# Altium schematic sheet MCU.SchDoc(MCU)
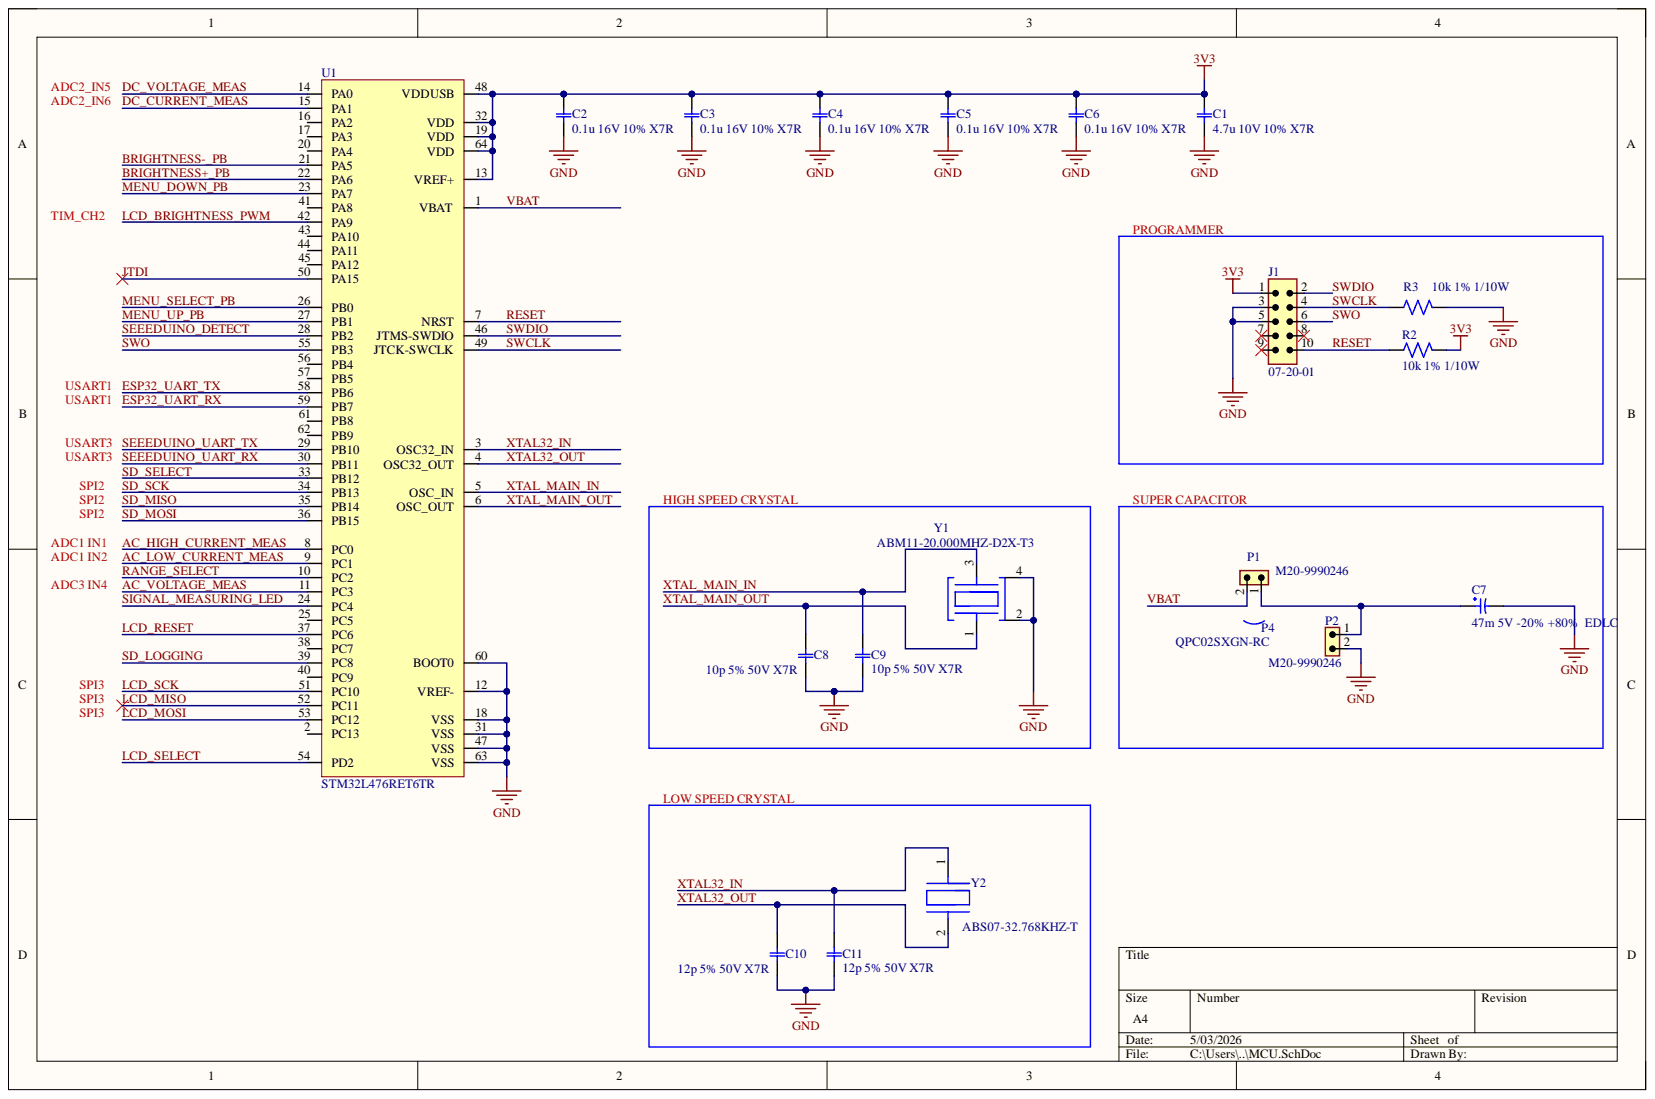
[diagram p0: MCU.SchDoc]

## Parts
C1  4.7u 10V 10% X7R  [0603-C]
C2  0.1u 16V 10% X7R  [0603-C]
C3  0.1u 16V 10% X7R  Dielectric: X7R  [0603-C]
C4  0.1u 16V 10% X7R  Dielectric: X7R  [0603-C]
C5  0.1u 16V 10% X7R  Dielectric: X7R  [0603-C]
C6  0.1u 16V 10% X7R  Dielectric: X7R  [0603-C]
C7  47m 5V -20% +80%  EDLC  [FC0H473ZFTBR24]
C8  10p 5% 50V X7R  value 10p  [0805-C]
C9  10p 5% 50V X7R  value 10p  [0805-C]
C10  12p 5% 50V X7R  value 12p  [0805-C]
C11  12p 5% 50V X7R  value 12p  [0805-C]
J1  07-20-01  [07-20-01]
P1  M20-9990246  [M20-9990246]
P2  M20-9990246  [M20-9990246]
P4  QPC02SXGN-RC  (mechanical)
R2  10k 1% 1/10W  Resistance Tolerance: 1%  [0603-R]
R3  10k 1% 1/10W  Resistance Tolerance: 1%  [0603-R]
U1  STM32L476RET6TR  [STM32L476RET6TR]
Y1  ABM11-20.000MHZ-D2X-T3  [ABM11-20.000MHZ-D2X-T3]
Y2  ABS07-32.768KHZ-T  [ABS07-32.768KHZ-T]

## Nets
3V3: C1.1 C2.1 C3.1 C4.1 C5.1 C6.1 J1.1 R2.1 U1.13(VREF+) U1.19(VDD) U1.32(VDD) U1.48(VDDUSB) U1.64(VDD)
GND: C1.2 C2.2 C3.2 C4.2 C5.2 C6.2 C7.1 C8.1 C9.2 C10.1 C11.1 J1.3 J1.5 P2.2 R3.1 U1.12(VREF-) U1.18(VSS) U1.31(VSS) U1.47(VSS) U1.60(BOOT0) U1.63(VSS) Y1.2 Y1.4
AC_HIGH_CURRENT_MEAS: U1.8(PC0)
AC_LOW_CURRENT_MEAS: U1.9(PC1)
AC_VOLTAGE_MEAS: U1.11(PC3)
BRIGHTNESS+_PB: U1.22(PA6)
BRIGHTNESS-_PB: U1.21(PA5)
DC_CURRENT_MEAS: U1.15(PA1)
DC_VOLTAGE_MEAS: U1.14(PA0)
ESP32_UART_RX: U1.59(PB7)
ESP32_UART_TX: U1.58(PB6)
JTDI: U1.50(PA15)
LCD_BRIGHTNESS_PWM: U1.42(PA9)
LCD_MISO: U1.52(PC11)
LCD_MOSI: U1.53(PC12)
LCD_RESET: U1.37(PC6)
LCD_SCK: U1.51(PC10)
LCD_SELECT: U1.54(PD2)
MENU_DOWN_PB: U1.23(PA7)
MENU_SELECT_PB: U1.26(PB0)
MENU_UP_PB: U1.27(PB1)
RANGE_SELECT: U1.10(PC2)
RESET: J1.10 R2.2 U1.7(NRST)
SD_LOGGING: U1.39(PC8)
SD_MISO: U1.35(PB14)
SD_MOSI: U1.36(PB15)
SD_SCK: U1.34(PB13)
SD_SELECT: U1.33(PB12)
SEEEDUINO_DETECT: U1.28(PB2)
SEEEDUINO_UART_RX: U1.30(PB11)
SEEEDUINO_UART_TX: U1.29(PB10)
SIGNAL_MEASURING_LED: U1.24(PC4)
SWCLK: J1.4 R3.2 U1.49(JTCK-SWCLK)
SWDIO: J1.2 U1.46(JTMS-SWDIO)
SWO: J1.6 U1.55(PB3)
VBAT: P1.2 U1.1(VBAT)
XTAL32_IN: C11.2 U1.3(OSC32_IN) Y2.1
XTAL32_OUT: C10.2 U1.4(OSC32_OUT) Y2.2
XTAL_MAIN_IN: C9.1 U1.5(OSC_IN) Y1.3
XTAL_MAIN_OUT: C8.2 U1.6(OSC_OUT) Y1.1
NetC7_2: C7.2 P1.1 P2.1

Not connected: J1 7 8 9; U1 2(PC13) 16(PA2) 17(PA3) 20(PA4) 25(PC5) 38(PC7) 40(PC9) 41(PA8) 43(PA10) 44(PA11) 45(PA12) 56(PB4) 57(PB5) 61(PB8) 62(PB9)

## Library data of the parts
C1 = GMC10X7R475K10NT: Ceramic Capacitor, Multilayer, Ceramic, 10V, 10% +Tol, 10% -Tol, X7R, 15% TC, 4.7uF, Surface Mount, 0603; Manufacturer: Cal-Chip Electronics; Value: 4.7µF; Category: Ceramic Capacitors; China RoHS: Compliant; Component Kind: Standard; ComponentLink1Description: https://datasheet.octopart.com:443/GMC10X7R475K10NT-Cal-Chip-Electronics-datasheet-182028815.pdf?src-supplier=IHS; ComponentLink1URL: https://datasheet.octopart.com:443/GMC10X7R475K10NT-Cal-Chip-Electronics-datasheet-182028815.pdf?src-supplier=IHS; Dielectric Material: Ceramic; Height: 900µm; Length: 1.6mm; Lifecycle Status: Production; Manufacturer Part Number: GMC10X7R475K10NT; Max Operating Temperature: 125°C; Min Operating Temperature: -55°C; Mounting Technology: Surface Mount; Number of Terminals: 2; Pricing: 1=0.26, 10=0.154, 50=0.1112, 100=0.0982, 500=0.07628, 1000=0.06951 (USD); REACH SVHC: Yes; RoHS: Compliant; Stock: 238375; Supplier 1: DigiKey; Supplier Part Number 1: 4713-GMC10X7R475K10NTCT-ND; Voltage Rating (DC): 10V; Width: 800µm; Library Name: tpmania.SchLib
C2-C6 = CC0603KRX7R7BB104: 0603 0.1 uF 16 V ±10 % Tolerance X7R SMT Multilayer Ceramic Capacitor; Manufacturer: Yageo; Insulation Resistance: 100GR; Value: 100nF; Case Code (Imperial): 0603; Case Code (Metric): 1608; Case/Package: 0603; Category: Ceramic Capacitors; Component Kind: Standard; ComponentLink1Description: https://datasheet.ciiva.com/11786/0900766b80d84f1d-11786077.pdf?src-supplier=Rs; ComponentLink1URL: https://datasheet.ciiva.com/11786/0900766b80d84f1d-11786077.pdf?src-supplier=Rs; ComponentLink2Description: https://datasheet.ciiva.com/144/mlccorderingcode-144182.pdf?src-supplier=Digikey; ComponentLink2URL: https://datasheet.ciiva.com/144/mlccorderingcode-144182.pdf?src-supplier=Digikey; ComponentLink3Description: https://datasheet.ciiva.com/144/mlccorderingcode-144183.pdf?src-supplier=Digikey; ComponentLink3URL: https://datasheet.ciiva.com/144/mlccorderingcode-144183.pdf?src-supplier=Digikey; ComponentLink4Description: https://datasheet.ciiva.com/144/mlccorderingcode-144184.pdf?src-supplier=Digikey; ComponentLink4URL: https://datasheet.ciiva.com/144/mlccorderingcode-144184.pdf?src-supplier=Digikey; ComponentLink5Description: https://datasheet.ciiva.com/7978/1489800-7978141.pdf?src-supplier=Element14; ComponentLink5URL: https://datasheet.ciiva.com/7978/1489800-7978141.pdf?src-supplier=Element14; ComponentLink6Description: https://datasheet.ciiva.com/11464/upy-gphc-x7r-6-3v-to-50v-8-11464735.pdf?src-supplier=Avnet; ComponentLink6URL: https://datasheet.ciiva.com/11464/upy-gphc-x7r-6-3v-to-50v-8-11464735.pdf?src-supplier=Avnet; ComponentLink7Description: https://datasheet.ciiva.com/28450/1489800-28450552.pdf?src-supplier=Element14; ComponentLink7URL: https://datasheet.ciiva.com/28450/1489800-28450552.pdf?src-supplier=Element14; ComponentLink8Description: https://datasheet.ciiva.com/46430/1734573-46430156.pdf?src-supplier=Element14; ComponentLink8URL: https://datasheet.ciiva.com/46430/1734573-46430156.pdf?src-supplier=Element14; ComponentLink9Description: https://datasheet.ciiva.com/8033/1734573-8033797.pdf?src-supplier=Element14; ComponentLink9URL: https://datasheet.ciiva.com/8033/1734573-8033797.pdf?src-supplier=Element14; ComponentLink10Description: https://datasheet.ciiva.com/8033/70765-8033799.pdf?src-supplier=Element14; ComponentLink10URL: https://datasheet.ciiva.com/8033/70765-8033799.pdf?src-supplier=Element14; ComponentLink11Description: https://datasheet.ciiva.com/15165/getdatasheetpartid-63554-15165387.pdf?src-supplier=Verical; ComponentLink11URL: https://datasheet.ciiva.com/15165/getdatasheetpartid-63554-15165387.pdf?src-supplier=Verical; ComponentLink12Description: https://datasheet.ciiva.com/13395/upy-gphc-x7r-6-3v-to-50v-8-13395674.pdf?src-supplier=Mouser; ComponentLink12URL: https://datasheet.ciiva.com/13395/upy-gphc-x7r-6-3v-to-50v-8-13395674.pdf?src-supplier=Mouser; Depth: 0.8mm; Halogen Free: Halogen Free; Height: 0.8mm; Lead Free: Lead Free; Length: 1.6mm; Manufacturer Part Number: CC0603KRX7R7BB104; Material: Ceramic; Max Operating Temperature: 125°C; Min Operating Temperature: -55°C; Mounting Technology: Surface Mount; Package Quantity: 1; Packaging: Tape and Reel; Pins: 2; Pricing: 1=0.08, 10=0.014, 50=0.012, 100=0.0096, 500=0.0072, 1000=0.0064 (USD); Radiation Hardening: No; Reach SVHC Compliant: No; RoHS: Yes; RoHS Compliant: Yes; Series: CC; Stock: 7111175; Supplier 1: Digikey; Supplier Part Number 1: 311-1088-1-ND; Termination: SMD/SMT; Thickness: 0.035inch; Tolerance: 10%; Voltage: 16V; Voltage Rating: 16V; Voltage Rating (DC): 16V; Width: 0.031inch; Library Name: tpmania.SchLib
  C2: Dielectric: X7R
C7 = FC0H473ZFTBR24: Cap Supercap 47000uF 5.5V -20% to 80% (10.5 X 5.5mm) SMD Cylindrical 50 Ohm 70C T/R; Manufacturer: KEMET; ESR (Equivalent Series Resistance): 50Ω; Value: 47mF; Category: Electric Double Layer Capacitors; Component Kind: Standard; ComponentLink1Description: https://datasheet.octopart.com:443/FC0H473ZFTBR24-KEMET-datasheet-176830268.pdf?src-supplier=IHS; ComponentLink1URL: https://datasheet.octopart.com:443/FC0H473ZFTBR24-KEMET-datasheet-176830268.pdf?src-supplier=IHS; ComponentLink2Description: https://datasheet.octopart.com:443/FC0H473ZFTBR24-KEMET-datasheet-140123098.pdf?src-supplier=Farnell; ComponentLink2URL: https://datasheet.octopart.com:443/FC0H473ZFTBR24-KEMET-datasheet-140123098.pdf?src-supplier=Farnell; ComponentLink3Description: https://datasheet.octopart.com:443/FC0H473ZFTBR24-KEMET-datasheet-101149853.pdf?src-supplier=Farnell; ComponentLink3URL: https://datasheet.octopart.com:443/FC0H473ZFTBR24-KEMET-datasheet-101149853.pdf?src-supplier=Farnell; ComponentLink4Description: https://datasheet.octopart.com:443/FC0H473ZFTBR24-KEMET-datasheet-181965052.pdf?src-supplier=Newark; ComponentLink4URL: https://datasheet.octopart.com:443/FC0H473ZFTBR24-KEMET-datasheet-181965052.pdf?src-supplier=Newark; ComponentLink5Description: https://datasheet.octopart.com:443/FC0H473ZFTBR24-KEMET-datasheet-181965051.pdf?src-supplier=Newark; ComponentLink5URL: https://datasheet.octopart.com:443/FC0H473ZFTBR24-KEMET-datasheet-181965051.pdf?src-supplier=Newark; ComponentLink6Description: https://datasheet.octopart.com:443/FC0H473ZFTBR24-Kemet-datasheet-20803799.pdf?src-supplier=Newark; ComponentLink6URL: https://datasheet.octopart.com:443/FC0H473ZFTBR24-Kemet-datasheet-20803799.pdf?src-supplier=Newark; ComponentLink7Description: https://datasheet.octopart.com:443/FC0H473ZFTBR24-KEMET-datasheet-76800834.pdf?src-supplier=Newark; ComponentLink7URL: https://datasheet.octopart.com:443/FC0H473ZFTBR24-KEMET-datasheet-76800834.pdf?src-supplier=Newark; ComponentLink8Description: https://datasheet.octopart.com:443/FC0H473ZFTBR24-KEMET-datasheet-76759449.pdf?src-supplier=Newark; ComponentLink8URL: https://datasheet.octopart.com:443/FC0H473ZFTBR24-KEMET-datasheet-76759449.pdf?src-supplier=Newark; ComponentLink9Description: https://datasheet.octopart.com:443/FC0H473ZFTBR24-Kemet-datasheet-62307655.pdf?src-supplier=element14+APAC; ComponentLink9URL: https://datasheet.octopart.com:443/FC0H473ZFTBR24-Kemet-datasheet-62307655.pdf?src-supplier=element14+APAC; ComponentLink10Description: https://datasheet.octopart.com:443/FC0H473ZFTBR24-Kemet-datasheet-62115098.pdf?src-supplier=element14+APAC; ComponentLink10URL: https://datasheet.octopart.com:443/FC0H473ZFTBR24-Kemet-datasheet-62115098.pdf?src-supplier=element14+APAC; ComponentLink11Description: https://datasheet.octopart.com:443/FC0H473ZFTBR24-KEMET-datasheet-104529960.pdf?src-supplier=TME; ComponentLink11URL: https://datasheet.octopart.com:443/FC0H473ZFTBR24-KEMET-datasheet-104529960.pdf?src-supplier=TME; ComponentLink12Description: https://datasheet.octopart.com:443/FC0H473ZFTBR24-KEMET-datasheet-104202293.pdf?src-supplier=TME; ComponentLink12URL: https://datasheet.octopart.com:443/FC0H473ZFTBR24-KEMET-datasheet-104202293.pdf?src-supplier=TME; Diameter: 10.5mm; Height: 5.5mm; Length: 10.8mm; Library Name: ENGG3800 schematic library.SchLib; Lifecycle Status: Production; Manufacturer Part Number: FC0H473ZFTBR24; Max Operating Temperature: 70°C; Min Operating Temperature: -25°C; Mounting Technology: Surface Mount; Pricing: 1=2.64, 10=1.822, 50=1.4956, 100=1.3949, 500=1.22452 (USD); REACH SVHC: Yes; RoHS: Compliant; Schedule B: 8532290040; Stock: 7158; Supplier 1: DigiKey; Supplier Part Number 1: 399-10938-1-ND; Voltage Rating (DC): 5.5V; Width: 10.8mm
C8 C9 = 64-02-01: Component Kind: Standard; Library Name: ENGG3800 schematic library.SchLib; Supplier 1: etsg; Supplier Part Number 1: 64-02-01
C10 C11 = 64-02-02: Component Kind: Standard; Library Name: ENGG3800 schematic library.SchLib; Supplier 1: etsg; Supplier Part Number 1: 64-02-02
J1 = 07-20-01: HEADER: J-link Connector / 10 pins / 1.27mm / Box Header / Through Hole; Component Kind: Standard; Library Name: ENGG3800 schematic library.SchLib; Supplier 1: etsg; Supplier Part Number 1: 07-20-01
P1 P2 = M20-9990246: 2.54mm (0.1") Pitch SIL Vertical PC Tail Pin Header, 6.1mm mating pin height, tin, 2 contacts; Manufacturer: Harwin; Connector Type: Male Pin; Contact Resistance: 30mΩ; Current Rating: 3A; Dielectric Material: Polyamide; Gender: Male; Insulation Resistance: 1GΩ; Number of Contacts: 2; Voltage Rating (AC): 750V; Case/Package: SIL; Category: Headers and Wire Housings; Color: Black; Component Kind: Standard; ComponentLink1Description: https://datasheet.octopart.com:443/M20-9990246-Harwin-datasheet-169407857.pdf?src-supplier=Newark; ComponentLink1URL: https://datasheet.octopart.com:443/M20-9990246-Harwin-datasheet-169407857.pdf?src-supplier=Newark; ComponentLink2Description: https://datasheet.octopart.com:443/M20-9990246-Harwin-datasheet-27378916.pdf?src-supplier=Newark; ComponentLink2URL: https://datasheet.octopart.com:443/M20-9990246-Harwin-datasheet-27378916.pdf?src-supplier=Newark; ComponentLink3Description: https://datasheet.octopart.com:443/M20-9990246-Harwin-datasheet-96624206.pdf?src-supplier=element14+APAC; ComponentLink3URL: https://datasheet.octopart.com:443/M20-9990246-Harwin-datasheet-96624206.pdf?src-supplier=element14+APAC; ComponentLink4Description: https://datasheet.octopart.com:443/M20-9990246-Harwin-datasheet-32864541.pdf?src-supplier=Farnell; ComponentLink4URL: https://datasheet.octopart.com:443/M20-9990246-Harwin-datasheet-32864541.pdf?src-supplier=Farnell; ComponentLink5Description: https://datasheet.octopart.com:443/M20-9990246-Harwin-datasheet-7538443.pdf?src-supplier=Farnell; ComponentLink5URL: https://datasheet.octopart.com:443/M20-9990246-Harwin-datasheet-7538443.pdf?src-supplier=Farnell; ComponentLink6Description: https://datasheet.octopart.com:443/M20-9990246-Harwin-datasheet-508868.pdf?src-supplier=Farnell; ComponentLink6URL: https://datasheet.octopart.com:443/M20-9990246-Harwin-datasheet-508868.pdf?src-supplier=Farnell; Contact Gender: Male; Contact Material: Brass; Contact Mating Length: 6.096mm; Contact Plating: Tin; Country of Origin: Taiwan; Depth: 2.54mm; Export Control Classification Number (ECCN) Code: EAR99; Harmonized Tariff Schedule (HTS) Code: 8536.69.40.40; Height: 8.99mm; Housing Color: Black; Housing Material: Nylon; Introduction Date: 2005-06-27; Lead Pitch: 2.54mm; Length: 5.08mm; Library Name: ENGG3800 schematic library.SchLib; Lifecycle Status: Production; Manufacturer Part Number: M20-9990246; Max Current Rating: 3A; Max Operating Temperature: 105°C; Min Operating Temperature: -40°C; Mounting Technology: Through Hole; Number of Positions: 2; Number of Rows: 1; Orientation: Straight; Packaging: Bulk; Pins: 2; Pitch: 2.54mm; Pricing: 1=0.1, 10=0.079, 100=0.0669, 500=0.0597, 1000=0.05684, 5000=0.05073, 10000=0.04831 (USD); Radiation Hardening: No; REACH SVHC: Yes; RoHS: Compliant; Stock: 152704; Supplier 1: DigiKey; Supplier Part Number 1: 952-2262-ND; Terminal Pitch: 2.54mm; Termination: Solder
P4 = QPC02SXGN-RC: 2 Position 2.54 mm Centerline Single Circuit Open Top Jumper; Manufacturer: Sullins; Current Rating: 3A; Number of Contacts: 2; Pitch: 2.54mm; Case/Package: 100; Category: Shunts and Jumpers; ComponentLink1Description: https://datasheet.octopart.com:443/QPC02SXGN-RC-Sullins-datasheet-138898435.pdf?src-supplier=ODG+(Origin+Data+Global); ComponentLink1URL: https://datasheet.octopart.com:443/QPC02SXGN-RC-Sullins-datasheet-138898435.pdf?src-supplier=ODG+(Origin+Data+Global); ComponentLink2Description: https://datasheet.octopart.com:443/QPC02SXGN-RC-Sullins-datasheet-12521006.pdf?src-supplier=IHS; ComponentLink2URL: https://datasheet.octopart.com:443/QPC02SXGN-RC-Sullins-datasheet-12521006.pdf?src-supplier=IHS; ComponentLink3Description: https://sigma.octopart.com:443/138898456/technical_drawing/Sullins-QPC02SXGN-RC.pdf?src-supplier=Upverter; ComponentLink3URL: https://sigma.octopart.com:443/138898456/technical_drawing/Sullins-QPC02SXGN-RC.pdf?src-supplier=Upverter; ComponentLink4Description: https://datasheet.octopart.com:443/QPC02SXGN-RC-Sullins-datasheet-41399305.pdf?src-supplier=Future+Electronics; ComponentLink4URL: https://datasheet.octopart.com:443/QPC02SXGN-RC-Sullins-datasheet-41399305.pdf?src-supplier=Future+Electronics; Contact Gender: Female; Contact Material: Brass; Contact Plating: Gold; Height: 6.17mm; Lead Free: Lead Free; Library Name: ENGG3800 schematic library.SchLib; Lifecycle Status: Production; Manufacturer Part Number: QPC02SXGN-RC; Max Operating Temperature: 85°C; Min Operating Temperature: -40°C; Number of Positions: 2; Packaging: Bulk; Pins: 1; Pricing: 1=0.1, 10=0.028, 25=0.026, 50=0.025, 100=0.0237, 250=0.02224, 500=0.02116, 2000=0.01918, 4000=0.01826 (USD); REACH SVHC: Yes; RoHS: Compliant; Stock: 1035793; Supplier 1: DigiKey; Supplier Part Number 1: S9337-ND
R2 R3 = RK73H1JTTD1002F: Resistor Thick Film 0603 10K Ohm 1% 1/10W ±100ppm/°C SMD Punched Paper Tape and Reel; Manufacturer: KOA Speer; Max Power Dissipation: 100mW; Value: 10kR; Voltage Rating: 50V; Case Code (Imperial): 0603; Case Code (Metric): 1608; Case/Package: 0603; Category: Chip SMD Resistors; Component Kind: Standard; ComponentLink1Description: https://datasheet.ciiva.com/5556/rk73h-5556565.pdf?src-supplier=Mouser; ComponentLink1URL: https://datasheet.ciiva.com/5556/rk73h-5556565.pdf?src-supplier=Mouser; ComponentLink2Description: https://datasheet.ciiva.com/48426/rk73h-series-eng-jap-tds-48426520.pdf?src-supplier=Distrelec; ComponentLink2URL: https://datasheet.ciiva.com/48426/rk73h-series-eng-jap-tds-48426520.pdf?src-supplier=Distrelec; ComponentLink3Description: https://datasheet.ciiva.com/7773/549017-7773368.pdf?src-supplier=Element14; ComponentLink3URL: https://datasheet.ciiva.com/7773/549017-7773368.pdf?src-supplier=Element14; ComponentLink4Description: https://datasheet.ciiva.com/15159/getdatasheetpartid-89857-15159884.pdf?src-supplier=Verical; ComponentLink4URL: https://datasheet.ciiva.com/15159/getdatasheetpartid-89857-15159884.pdf?src-supplier=Verical; Construction: Rectangular; Depth: 0.8mm; Features: Moisture Resistant,Automotive AEC-Q200; Height: 0.45mm; Lead Free: Lead Free; Length: 1.6mm; Manufacturer Part Number: RK73H1JTTD1002F; Max Operating Temperature: 155°C; Military Standard: Not; Min Operating Temperature: -55°C; Number of Terminations: 2; Package Quantity: 5000; Packaging: Tape and Reel; Pins: 2; Power Rating: 100mW; Pricing: 1=0.1, 10=0.026, 100=0.0124, 1000=0.0068, 2500=0.00557 (USD); Ratings: AEC-Q200; Reach SVHC Compliant: Unknown; Resistor Type: Thick Film; RoHS: Yes; RoHS Compliant: Yes; Series: RK73H; Stock: 1507817; Supplier 1: Digikey; Supplier Part Number 1: 2019-RK73H1JTTD1002FCT-ND; Temperature Coefficient: 100ppm/°C; Termination: SMD/SMT; Tolerance: 1%; Voltage Rating (DC): 50V; Width: 0.8mm; Library Name: tpmania.SchLib
U1 = STM32L476RET6TR: Ultra-low-power with FPU ARM Cortex-M4 MCU 80 MHz with 512 Kbytes Flash, LCD, USB OTG; Manufacturer: STMicroelectronics; ADC Channels (Resolution): 16-Ch 12-Bit; Clock Frequency: 48MHz; DAC Channels (Resolution): 2 Ch 12-Bit; EEPROM Memory Size: 0B; Flash Memory Size: 512kB; Frequency: 80MHz; Interface: CAN, USB; Max Supply Voltage: 3.6V; Min Supply Voltage: 1.71V; Nominal Supply Current: 30nA; Number of Channels: 16; Number of D/A Converters: 2; Number of I2C Channels: 3; Number of I/Os: 51; Number of Timers/Counters: 17; Operating Supply Voltage: 1.8V; RAM Size: 128kB; Category: Microcontrollers; China RoHS: Compliant; Component Kind: Standard; ComponentLink1Description: https://datasheet.octopart.com:443/STM32L476RET6TR-STMicroelectronics-datasheet-113979974.pdf?src-supplier=ODG+(Origin+Data+Global); ComponentLink1URL: https://datasheet.octopart.com:443/STM32L476RET6TR-STMicroelectronics-datasheet-113979974.pdf?src-supplier=ODG+(Origin+Data+Global); ComponentLink2Description: https://datasheet.octopart.com:443/STM32L476RET6TR-STMicroelectronics-datasheet-59262024.pdf?src-supplier=SHENGYU+ELECTRONICS; ComponentLink2URL: https://datasheet.octopart.com:443/STM32L476RET6TR-STMicroelectronics-datasheet-59262024.pdf?src-supplier=SHENGYU+ELECTRONICS; Core Architecture: ARM Cortex-M4; Height - Seated (Max): 1.6mm; Length: 10mm; Library Name: ENGG3800 schematic library.SchLib; Lifecycle Status: Production; Manufacturer Part Number: STM32L476RET6TR; Max Operating Temperature: 85°C; Memory Format: FLASH; Min Operating Temperature: -40°C; Number of Interrupts: 16; Number of SPI Channels: 3; Number of Terminals: 64; Number of UART Channels: 2; Number of USART Channels: 3; Peripherals: LCD; Pricing: 1000=3.86439, 2000=3.83136, 4000=3.77687, 8000=3.72237, 16000=3.66787 (USD); REACH SVHC: Yes; RoHS: Compliant; Schedule B: 8542310000; Stock: 3000; Supplier 1: Digikey; Supplier Part Number 1: 497-19381-1-ND; Temperature Grade: Industrial; Terminal Pitch: 500µm; Width: 10mm
Y1 = ABM11-20.000MHZ-D2X-T3: Crystal 20MHz ±20ppm (Tol) ±20ppm (Stability) 10pF FUND 120Ohm 4-Pin Ultra Mini-CSMD T/R; Manufacturer: Abracon; ESR: 120R; Case/Package: SMD/SMT; Category: Crystals; Component Kind: Standard; ComponentLink1Description: https://datasheet.ciiva.com/856/abm11-856262.pdf?src-supplier=Digikey; ComponentLink1URL: https://datasheet.ciiva.com/856/abm11-856262.pdf?src-supplier=Digikey; ComponentLink2Description: https://datasheet.ciiva.com/856/abm11-856263.pdf?src-supplier=Digikey; ComponentLink2URL: https://datasheet.ciiva.com/856/abm11-856263.pdf?src-supplier=Digikey; ComponentLink3Description: https://datasheet.ciiva.com/856/abm11-856264.pdf?src-supplier=Digikey; ComponentLink3URL: https://datasheet.ciiva.com/856/abm11-856264.pdf?src-supplier=Digikey; ESR (Equivalent Series Resistance): 120R; Frequency: 20MHz; Frequency Stability: 20ppm; Frequency Tolerance: 20ppm; Height: 0.59mm; Length: 2mm; Library Name: ENGG3800 schematic library.SchLib; Load Capacitance: 10pF; Manufacturer Part Number: ABM11-20.000MHZ-D2X-T3; Max Operating Temperature: 85°C; Min Operating Temperature: -40°C; Mounting Technology: Surface Mount; Package Quantity: 3000; Packaging: Tape and Reel; Pins: 4; Pricing: 1=1.04, 10=0.832, 50=0.732, 100=0.714, 1000=0.64, 10000=0.575 (USD); Reach SVHC Compliant: No; RoHS: Yes; RoHS Compliant: Yes; Series: ABM11; Stock: 11493; Supplier 1: Digikey; Supplier Part Number 1: 815-ABM11-20-D2XT3; Termination: SMD/SMT; Tolerance: 20ppm; Width: 1.6mm
Y2 = ABS07-32.768KHZ-T: Crystal 0.032768MHz ±20ppm (Tol) 12.5pF Flexural 70000Ohm 2-Pin CSMD T/R; Manufacturer: Abracon; ESR: 70kΩ; Frequency Stability: 144ppm; Frequency Tolerance: 0.002%; Case/Package: CSMD; Category: Crystals; China RoHS: Compliant; Component Kind: Standard; ComponentLink1Description: https://datasheet.octopart.com:443/ABS07-32.768KHZ-T-Abracon-datasheet-166693031.pdf?src-supplier=Master+Electronics; ComponentLink1URL: https://datasheet.octopart.com:443/ABS07-32.768KHZ-T-Abracon-datasheet-166693031.pdf?src-supplier=Master+Electronics; ComponentLink2Description: https://datasheet.octopart.com:443/ABS07-32.768KHZ-T-Abracon-datasheet-103422647.pdf?src-supplier=JRH+Electronics; ComponentLink2URL: https://datasheet.octopart.com:443/ABS07-32.768KHZ-T-Abracon-datasheet-103422647.pdf?src-supplier=JRH+Electronics; ComponentLink3Description: https://datasheet.octopart.com:443/ABS07-32.768KHZ-T-Abracon-datasheet-12512028.pdf?src-supplier=Upverter; ComponentLink3URL: https://datasheet.octopart.com:443/ABS07-32.768KHZ-T-Abracon-datasheet-12512028.pdf?src-supplier=Upverter; ComponentLink4Description: https://datasheet.octopart.com:443/ABS07-32.768KHZ-T-Abracon-datasheet-10031075.pdf?src-supplier=Upverter; ComponentLink4URL: https://datasheet.octopart.com:443/ABS07-32.768KHZ-T-Abracon-datasheet-10031075.pdf?src-supplier=Upverter; ComponentLink5Description: https://datasheet.octopart.com:443/ABS07-32.768KHZ-T-Abracon-datasheet-8326266.pdf?src-supplier=Upverter; ComponentLink5URL: https://datasheet.octopart.com:443/ABS07-32.768KHZ-T-Abracon-datasheet-8326266.pdf?src-supplier=Upverter; ComponentLink6Description: https://datasheet.octopart.com:443/ABS07-32.768KHZ-T-Abracon-datasheet-121082.pdf?src-supplier=Upverter; ComponentLink6URL: https://datasheet.octopart.com:443/ABS07-32.768KHZ-T-Abracon-datasheet-121082.pdf?src-supplier=Upverter; ComponentLink7Description: https://datasheet.octopart.com:443/ABS07-32.768KHZ-T-Abracon-datasheet-41191559.pdf?src-supplier=element14+APAC; ComponentLink7URL: https://datasheet.octopart.com:443/ABS07-32.768KHZ-T-Abracon-datasheet-41191559.pdf?src-supplier=element14+APAC; ComponentLink8Description: https://datasheet.octopart.com:443/ABS07-32.768KHZ-T-Abracon-datasheet-15206620.pdf?src-supplier=Newark; ComponentLink8URL: https://datasheet.octopart.com:443/ABS07-32.768KHZ-T-Abracon-datasheet-15206620.pdf?src-supplier=Newark; ComponentLink9Description: https://datasheet.octopart.com:443/ABS07-32.768KHZ-T-Abracon-datasheet-37124839.pdf?src-supplier=Farnell; ComponentLink9URL: https://datasheet.octopart.com:443/ABS07-32.768KHZ-T-Abracon-datasheet-37124839.pdf?src-supplier=Farnell; ComponentLink10Description: https://datasheet.octopart.com:443/ABS07-32.768KHZ-T-Abracon-datasheet-128558976.pdf?src-supplier=X-ON; ComponentLink10URL: https://datasheet.octopart.com:443/ABS07-32.768KHZ-T-Abracon-datasheet-128558976.pdf?src-supplier=X-ON; ComponentLink11Description: https://datasheet.octopart.com:443/ABS07-32.768KHZ-T-Abracon-datasheet-49826663.pdf?src-supplier=TME; ComponentLink11URL: https://datasheet.octopart.com:443/ABS07-32.768KHZ-T-Abracon-datasheet-49826663.pdf?src-supplier=TME; ComponentLink12Description: https://datasheet.octopart.com:443/ABS07-32.768KHZ-T-Abracon-datasheet-15994676.pdf?src-supplier=TME; ComponentLink12URL: https://datasheet.octopart.com:443/ABS07-32.768KHZ-T-Abracon-datasheet-15994676.pdf?src-supplier=TME; ComponentLink13Description: http://sigma.octopart.com:80/conflict_mineral_statement/Abracon-company-27.pdf?src-supplier=Compliance+Statements; ComponentLink13URL: http://sigma.octopart.com:80/conflict_mineral_statement/Abracon-company-27.pdf?src-supplier=Compliance+Statements; Country of Origin: Mainland China; Depth: 1.5mm; ESR (Equivalent Series Resistance): 70kΩ; Export Control Classification Number (ECCN) Code: EAR99; Frequency: 32.768kHz; Harmonized Tariff Schedule (HTS) Code: 8541.60.00.10; Height: 900µm; Introduction Date: 2007-01-08; Lead Free: Lead Free; Length: 3.2mm; Library Name: ENGG3800 schematic library.SchLib; Lifecycle Status: Production; Load Capacitance: 12.5pF; Manufacturer Part Number: ABS07-32.768KHZ-T; Max Operating Temperature: 85°C; Min Operating Temperature: -40°C; Mounting Technology: Surface Mount; Packaging: Tape and Reel; Pins: 2; Pricing: 3000=0.19444 (USD); Radiation Hardening: No; REACH SVHC: Yes; RoHS: Compliant; Schedule B: 8541600025, 8541600025|8541600025, 8541600025|8541600025|8541600025, 8541600025|8541600025|8541600025|8541600025; Stock: 81000; Supplier 1: Digikey; Supplier Part Number 1: 535-9542-1-ND; Termination: SMD/SMT; Tolerance: 0.002%; Value: 12.5pF; Width: 1.5494mm
